# Altium schematic sheet MOTOR.SchDoc(MOTOR)
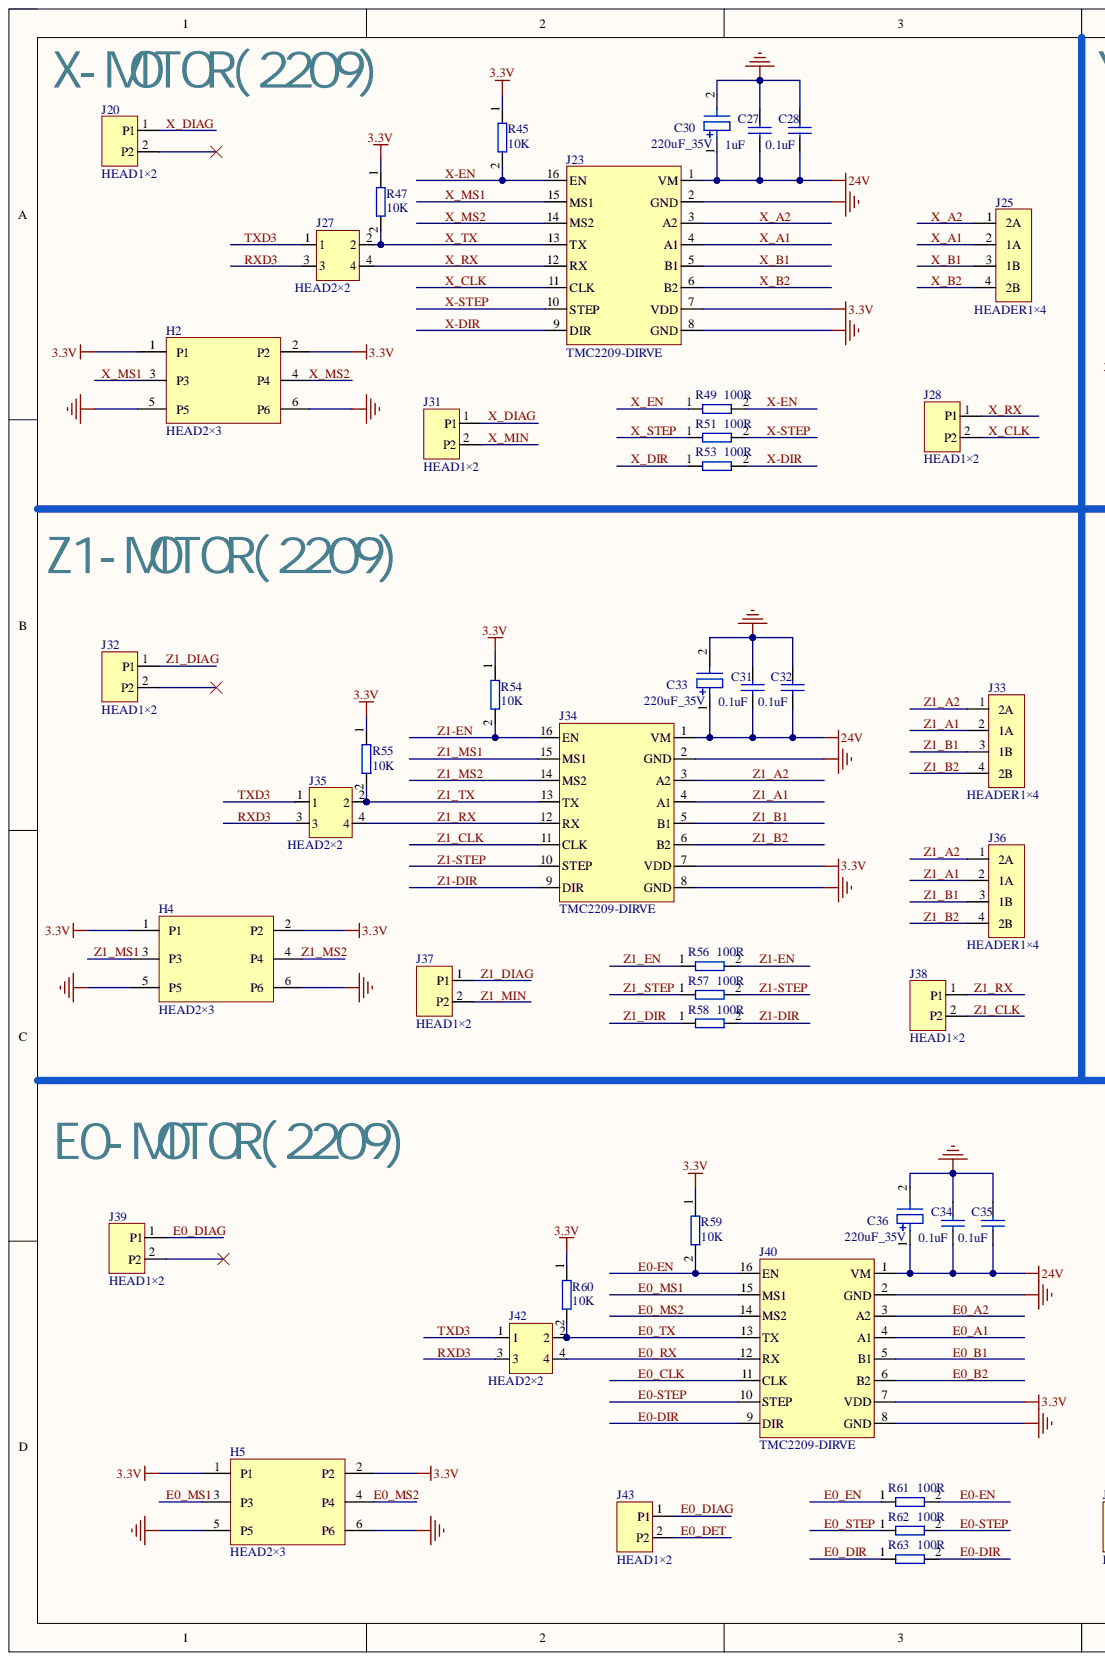
[diagram p0: MOTOR.SchDoc, left]
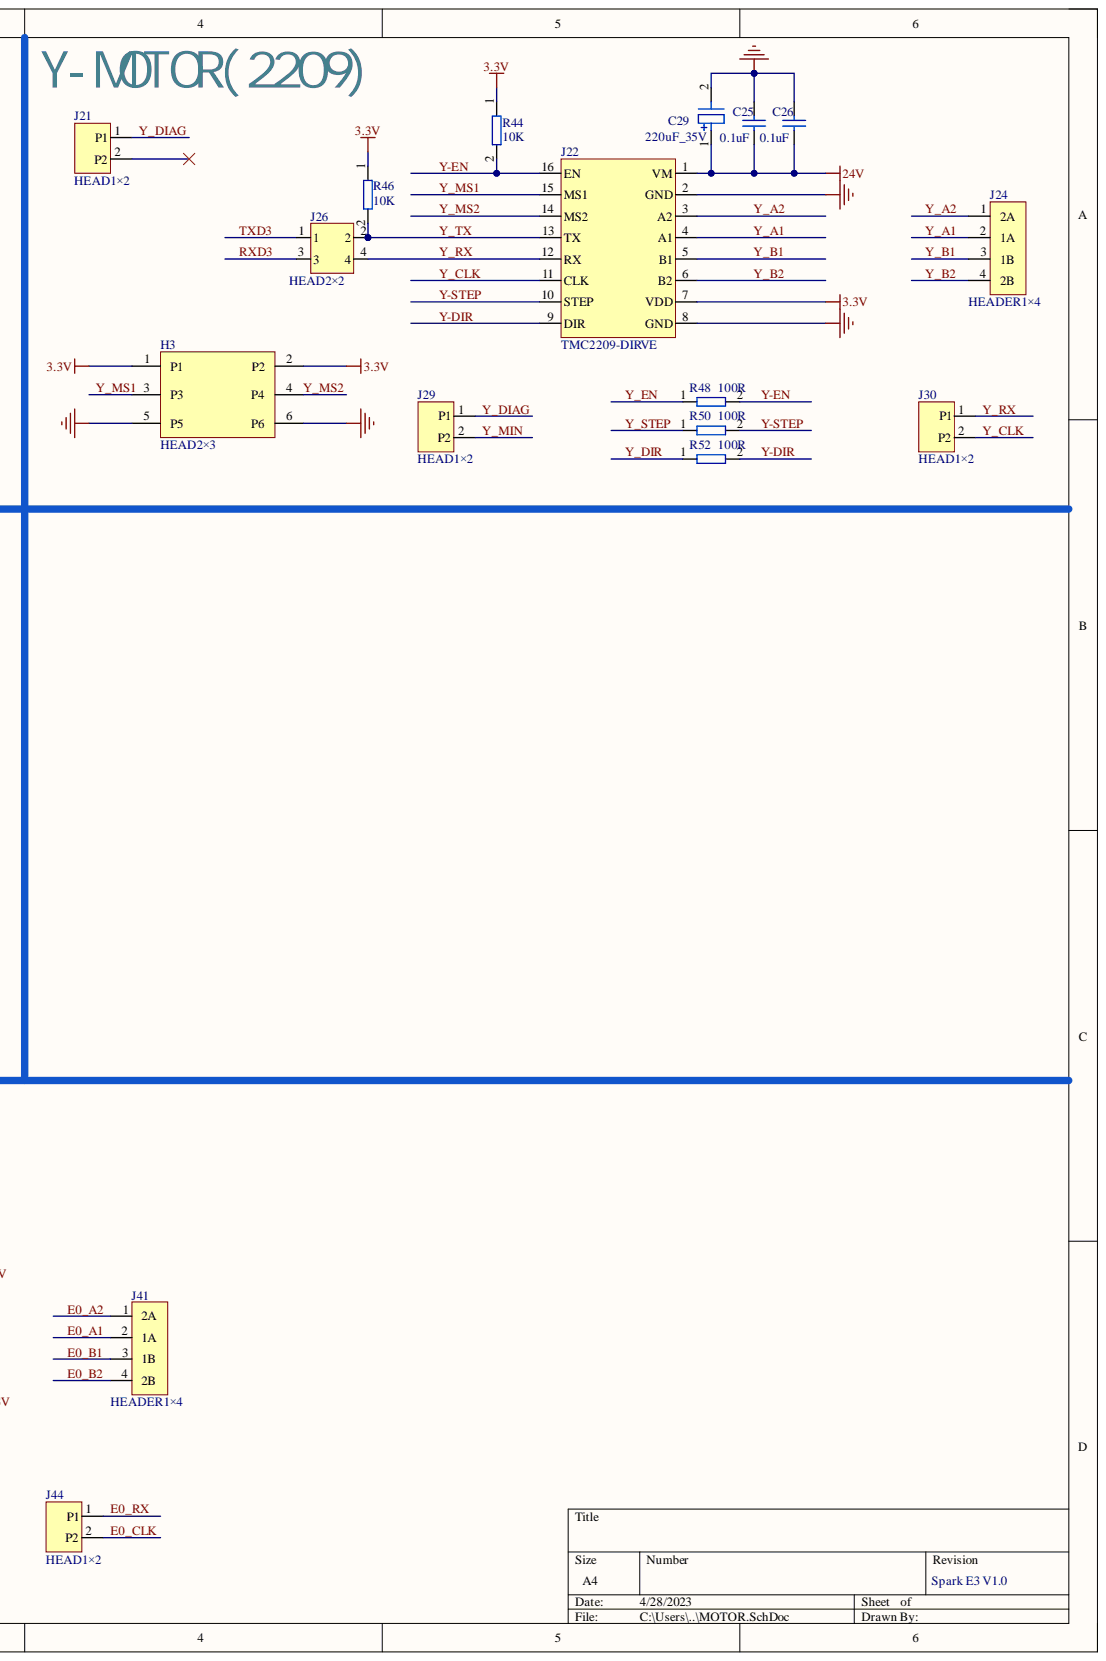
[diagram p1: MOTOR.SchDoc, right]

## Parts
C25  0.1uF  [C0603]
C26  0.1uF  [C0603]
C27  1uF  [C0603]
C28  0.1uF  [C0603]
C29  220uF_35V  [SMT-100uF/16V -6.3mm*7.7mm]
C30  220uF_35V  [SMT-100uF/16V -6.3mm*7.7mm]
C31  0.1uF  [C0603]
C32  0.1uF  [C0603]
C33  220uF_35V  [SMT-100uF/16V -6.3mm*7.7mm]
C34  0.1uF  [C0603]
C35  0.1uF  [C0603]
C36  220uF_35V  [SMT-100uF/16V -6.3mm*7.7mm]
H2  HEAD2×3  [双排针/2.54/3]
H3  HEAD2×3  [双排针/2.54/3]
H4  HEAD2×3  [双排针/2.54/3]
H5  HEAD2×3  [双排针/2.54/3]
J20  HEAD1×2  [TMC2209-DIAG]
J21  HEAD1×2  [TMC2209-DIAG]
J22  TMC2209-DIRVE  [TMC2209模块]
J23  TMC2209-DIRVE  [TMC2209模块]
J24  HEADER1×4  [CN/2.54/4]
J25  HEADER1×4  [CN/2.54/4]
J26  HEAD2×2  [双排针/2.54/2]
J27  HEAD2×2  [双排针/2.54/2]
J28  HEAD1×2  [DIP/2.54/2p]
J29  HEAD1×2  [DIP/2.54/2p]
J30  HEAD1×2  [DIP/2.54/2p]
J31  HEAD1×2  [DIP/2.54/2p]
J32  HEAD1×2  [TMC2209-DIAG]
J33  HEADER1×4  [CN/2.54/4]
J34  TMC2209-DIRVE  [TMC2209模块]
J35  HEAD2×2  [双排针/2.54/2]
J36  HEADER1×4  [CN/2.54/4]
J37  HEAD1×2  [DIP/2.54/2p]
J38  HEAD1×2  [DIP/2.54/2p]
J39  HEAD1×2  [TMC2209-DIAG]
J40  TMC2209-DIRVE  [TMC2209模块]
J41  HEADER1×4  [CN/2.54/4]
J42  HEAD2×2  [双排针/2.54/2]
J43  HEAD1×2  [DIP/2.54/2p]
J44  HEAD1×2  [DIP/2.54/2p]
R44  10K  [R0603]
R45  10K  [R0603]
R46  10K  [R0603]
R47  10K  [R0603]
R48  100R  [R0603]
R49  100R  [R0603]
R50  100R  [R0603]
R51  100R  [R0603]
R52  100R  [R0603]
R53  100R  [R0603]
R54  10K  [R0603]
R55  10K  [R0603]
R56  100R  [R0603]
R57  100R  [R0603]
R58  100R  [R0603]
R59  10K  [R0603]
R60  10K  [R0603]
R61  100R  [R0603]
R62  100R  [R0603]
R63  100R  [R0603]

## Nets
3.3V: H2.1(P1) H2.2(P2) H3.1(P1) H3.2(P2) H4.1(P1) H4.2(P2) H5.1(P1) H5.2(P2) J22.7(VDD) J23.7(VDD) J34.7(VDD) J40.7(VDD) R44.1 R45.1 R46.1 R47.1 R54.1 R55.1 R59.1 R60.1
24V: C25.2 C26.2 C27.2 C28.2 C29.1 C30.1 C31.2 C32.2 C33.1 C34.2 C35.2 C36.1 J22.1(VM) J23.1(VM) J34.1(VM) J40.1(VM)
GND: C25.1 C26.1 C27.1 C28.1 C29.2 C30.2 C31.1 C32.1 C33.2 C34.1 C35.1 C36.2 H2.5(P5) H2.6(P6) H3.5(P5) H3.6(P6) H4.5(P5) H4.6(P6) H5.5(P5) H5.6(P6) J22.2(GND) J22.8(GND) J23.2(GND) J23.8(GND) J34.2(GND) J34.8(GND) J40.2(GND) J40.8(GND)
E0-DIR: J40.9(DIR) R63.2
E0-EN: J40.16(EN) R59.2 R61.2
E0-STEP: J40.10(STEP) R62.2
E0_A1: J40.4(A1) J41.2(1A)
E0_A2: J40.3(A2) J41.1(2A)
E0_B1: J40.5(B1) J41.3(1B)
E0_B2: J40.6(B2) J41.4(2B)
E0_CLK: J40.11(CLK) J44.2
E0_DET: J43.2
E0_DIAG: J39.1 J43.1
E0_DIR: R63.1
E0_EN: R61.1
E0_MS1: H5.3(P3) J40.15(MS1)
E0_MS2: H5.4(P4) J40.14(MS2)
E0_RX: J40.12(RX) J42.4 J44.1
E0_STEP: R62.1
E0_TX: J40.13(TX) J42.2 R60.2
RXD3: J26.3 J27.3 J35.3 J42.3
TXD3: J26.1 J27.1 J35.1 J42.1
X-DIR: J23.9(DIR) R53.2
X-EN: J23.16(EN) R45.2 R49.2
X-STEP: J23.10(STEP) R51.2
X_A1: J23.4(A1) J25.2(1A)
X_A2: J23.3(A2) J25.1(2A)
X_B1: J23.5(B1) J25.3(1B)
X_B2: J23.6(B2) J25.4(2B)
X_CLK: J23.11(CLK) J28.2
X_DIAG: J20.1 J31.1
X_DIR: R53.1
X_EN: R49.1
X_MIN: J31.2
X_MS1: H2.3(P3) J23.15(MS1)
X_MS2: H2.4(P4) J23.14(MS2)
X_RX: J23.12(RX) J27.4 J28.1
X_STEP: R51.1
X_TX: J23.13(TX) J27.2 R47.2
Y-DIR: J22.9(DIR) R52.2
Y-EN: J22.16(EN) R44.2 R48.2
Y-STEP: J22.10(STEP) R50.2
Y_A1: J22.4(A1) J24.2(1A)
Y_A2: J22.3(A2) J24.1(2A)
Y_B1: J22.5(B1) J24.3(1B)
Y_B2: J22.6(B2) J24.4(2B)
Y_CLK: J22.11(CLK) J30.2
Y_DIAG: J21.1 J29.1
Y_DIR: R52.1
Y_EN: R48.1
Y_MIN: J29.2
Y_MS1: H3.3(P3) J22.15(MS1)
Y_MS2: H3.4(P4) J22.14(MS2)
Y_RX: J22.12(RX) J26.4 J30.1
Y_STEP: R50.1
Y_TX: J22.13(TX) J26.2 R46.2
Z1-DIR: J34.9(DIR) R58.2
Z1-EN: J34.16(EN) R54.2 R56.2
Z1-STEP: J34.10(STEP) R57.2
Z1_A1: J33.2(1A) J34.4(A1) J36.2(1A)
Z1_A2: J33.1(2A) J34.3(A2) J36.1(2A)
Z1_B1: J33.3(1B) J34.5(B1) J36.3(1B)
Z1_B2: J33.4(2B) J34.6(B2) J36.4(2B)
Z1_CLK: J34.11(CLK) J38.2
Z1_DIAG: J32.1 J37.1
Z1_DIR: R58.1
Z1_EN: R56.1
Z1_MIN: J37.2
Z1_MS1: H4.3(P3) J34.15(MS1)
Z1_MS2: H4.4(P4) J34.14(MS2)
Z1_RX: J34.12(RX) J35.4 J38.1
Z1_STEP: R57.1
Z1_TX: J34.13(TX) J35.2 R55.2

Not connected: J20 2; J21 2; J32 2; J39 2

## Library data of the parts
C25-C28 C31 C32 C34 C35 = 电容: Component Kind: Standard; LatestRevisionDate: 17-Jul-2002; LatestRevisionNote: Re-released for DXP Platform.; PackageDescription: Radial Cap, Thru-Hole; 2 Leads; 0.3 in Pin Spacing; PackageReference: RAD-0.3; Published: 8-Jun-2000; Signal Integrity: Cap; Simulation: CAP; Value: ?; Library Name: MYschlib.SchLib; Publisher: Altium Limited
C29 C30 C33 C36 = 电解电容: Component Kind: Standard; VALUE: ?; Library Name: MYschlib.SchLib
J26 J27 J35 J42 = Component_1: Component Kind: Standard; Library Name: Schlib1.SchLib
R44-R63 = 电阻: Component Kind: Standard; value: ?; Library Name: MYschlib.SchLib
H2-H5 = HEAD2×3: Component Kind: Standard; Library Name: jietai-ADLB.SchLib
J20 J21 J28-J32 J37-J39 J43 J44 = HEAD1×2: Component Kind: Standard; Library Name: jietai-ADLB.SchLib
J22 J23 J34 J40 = TMC2209-DIRVE: Component Kind: Standard; Library Name: jietai-ADLB.SchLib
J24 J25 J33 J36 J41 = HEADER1×4: Component Kind: Standard; Library Name: jietai-ADLB.SchLib
